# Altium schematic sheet 2-Power.SchDoc(2-Power)
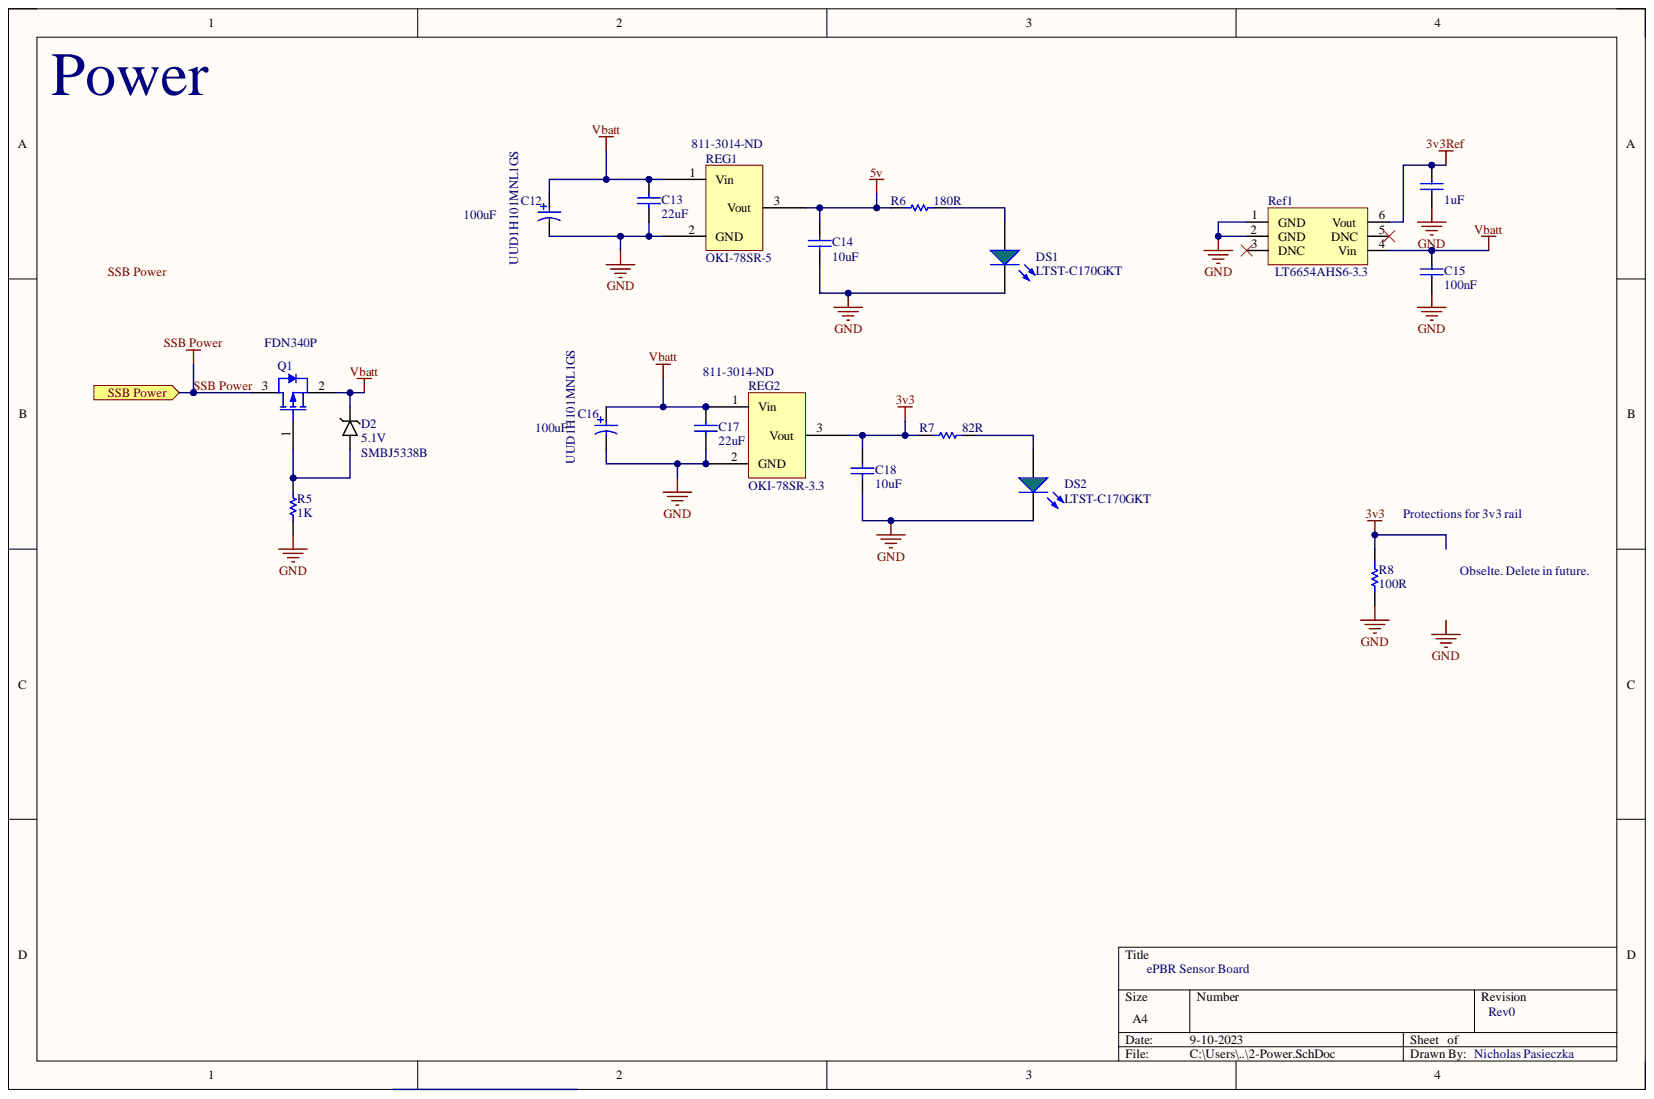
[diagram p0: 2-Power.SchDoc]

## Parts
C12  UUD1H101MNL1GS  [NIC-CAPA2-8X10_V]
C13  value 22uF  [C1210_M]  (hidden comment: Cap)
C14  value 10uF  [C1210_M]  (hidden comment: Cap)
C15  value 100nF  [1608[0603]]  (hidden comment: Cap)
C16  UUD1H101MNL1GS  [NIC-CAPA2-8X10_V]
C17  value 22uF  [C1210_M]  (hidden comment: Cap)
C18  value 10uF  [C1210_M]  (hidden comment: Cap)
D2  Part Number: SMBJ5338B  Reverse Voltage: 5.1V  [DO-214AA (SMB)]  (hidden comment: Zener Diode, 5.1V, 5W SMBJ5338B)
DS1  LTST-C170GKT  [LTST-C170GKT_V]
DS2  LTST-C170GKT  [LTST-C170GKT_V]
Q1  FDN340P  [FAIR-SSOT3L-3_V]
R5  value 1K  [1608[0603]]  (hidden comment: Res1)
R6  value 180R  [1608[0603]]  (hidden comment: Res1)
R7  value 82R  [1608[0603]]  (hidden comment: Res1)
R8  100R  [RESC1206(3216)_M]
Ref1  LT6654AHS6-3.3  [TSOT-23 S6]
REG1  OKI-78SR-5  Supplier Part Number 2: 811-3014-ND  [OKI-78SR-5/1.5-W - Horizontal]
REG2  OKI-78SR-3.3  Supplier Part Number 2: 811-3014-ND  [Reg - TH, 3 pin, 100 mil Pitch, VTL]
  value 1uF  [1608[0603]]  (hidden comment: Cap)

## Ports
SSB Power

## Nets
3v3: C18.2 R7.1 R8.2 REG2.3
3v3Ref: Ref1.6(Vout) .2
5v: C14.2 R6.1 REG1.3
GND: C12.2 C13.1 C14.1 C15.1 C16.2 C17.1 C18.1 DS1.2 DS2.2 R5.1 R8.1 Ref1.1(GND) Ref1.2(GND) REG1.2 REG2.2 .1
Vbatt: C12.1 C13.2 C15.2 C16.1 C17.2 D2.K Q1.2 Ref1.4(Vin) REG1.1 REG2.1
SSB Power: Q1.3 ; port SSB Power
NetD2_A: D2.A Q1.1 R5.2
NetDS1_1: DS1.1 R6.2
NetDS2_1: DS2.1 R7.2

Not connected: Ref1 3(DNC) 5(DNC)

## Library data of the parts
C12 C16 = CMP-0687-00073-1: Aluminum Electrolytic Capacitor, UD Series, Low Impedance, 100 uF, 50 V, + / - 20%, -55 to 105 degC, Chip Type, 8 x 10 mm D x L, Pb-Free, Reel; Manufacturer: Nichicon; Capacitance: 100µF; Endurance Time: 5000 h; Impedance: 0.34 Ohm; Lifetime @ Temp.: 5000 Hrs @ 105°C; Packing: Dia382mm Reel; Polarization: Polar; Rated Capacitance: 100 uF; Rated Ripple Current (at 100kHz): 300 mA; Rated Voltage: 50 V; Ripple Current: 300mA; Surface Mount Land Size: 0.327" L x 0.327" W (8.30mm x 8.30mm); Tolerance Rated Capacitance: -20 to 20%; Voltage Rating: 50V; Applications: General Purpose; Category: Capacitors; Component Kind: Standard; ComponentLink1Description: Manufacturer URL; ComponentLink1URL: http://www.nichicon.co.jp/; ComponentLink2Description: Datasheet; ComponentLink2URL: http://products.nichicon.co.jp/en/pdf/XJA043/e-ud.pdf; ComponentLink3Description: Package Specification; ComponentLink3URL: http://products.nichicon.co.jp/en/pdf/XJA043/e-ud.pdf; ComponentLink4Description: http://nichicon-us.com/english/products/pdfs/e-uud.pdf; ComponentLink4URL: http://nichicon-us.com/english/products/pdfs/e-uud.pdf; DatasheetVersion: 08/2012; Diameter: 8 mm; ESR (Equivalent Series Resistance): -; Height - Seated (Max): 0.394" (10.00mm); Lead Spacing: -; Length: 10 mm; Library Name: Altium Content Vault; Manufacturer Part Number: UUD1H101MNL1GS; Mounting Technology: Surface Mount; Mounting Type: Surface Mount; Operating Temperature: -55°C ~ 105°C; Package / Case: Radial, Can - SMD; PackageDescription: 2-Pin Surface Mount Device, Diameter 8 mm, Height 10 mm, Pitch 6.5 mm; PackageReference: CAPAE-D8H10; Packaging: Cut Tape (CT); Part Status: Active; PartNumber: UUD1H101MNL1GS; Pricing 1: 1=0.92, 10=0.685, 25=0.5868, 50=0.5478, 100=0.4696, 250=0.43044 (CAD); RoHS: TRUE; Size / Dimension: 0.315" Dia (8.00mm); Stock 1: 65948; Supplier 1: Digi-Key; Supplier Part Number 1: 493-2306-1-ND; Tolerance: ±20%
C13 C17 = Cap: Capacitor; Manufacturer: Yageo; Manufacturer Part Number: CC1210MKX5R8BB226; Capacitance: 22µF; Temperature Coefficient: X5R; Tolerance: ±20%; Voltage - Rated: 25V; Applications: General Purpose; Category: Capacitors; Component Kind: Standard; ComponentLink1Description: http://www.yageo.com/documents/recent/UPY-GPHC_X5R_4V-to-50V_23.pdf; ComponentLink1URL: http://www.yageo.com/documents/recent/UPY-GPHC_X5R_4V-to-50V_23.pdf; Failure Rate: -; Features: -; Height - Seated (Max): -; Lead Spacing: -; Lead Style: -; Library Name: Miscellaneous Devices.IntLib; Mounting Type: Surface Mount, MLCC; Operating Temperature: -55°C ~ 85°C; Package / Case: 1210 (3225 Metric); Packaging: Cut Tape (CT); Part Status: Active; Pricing 1: 1=1, 10=0.703, 25=0.5996, 50=0.5314, 100=0.4628, 250=0.39424 (CAD); Ratings: -; RoHS: RoHS Compliant; Simulation: CAP; Size / Dimension: 0.126" L x 0.098" W (3.20mm x 2.50mm); Stock 1: 128; Supplier 1: Digi-Key; Supplier Part Number 1: 311-2056-1-ND; Thickness (Max): 0.110" (2.80mm)
C14 C18 = Cap: Capacitor; Manufacturer: Yageo; Manufacturer Part Number: CC1210ZKY5V8BB106; Capacitance: 10µF; Temperature Coefficient: Y5V (F); Tolerance: -20%, +80%; Voltage - Rated: 25V; Applications: General Purpose; Category: Capacitors; Component Kind: Standard; ComponentLink1Description: http://www.yageo.com/documents/recent/UPY-GPHC_Y5V_6.3V-to-50V_7.pdf; ComponentLink1URL: http://www.yageo.com/documents/recent/UPY-GPHC_Y5V_6.3V-to-50V_7.pdf; Failure Rate: -; Features: -; Height - Seated (Max): -; Lead Spacing: -; Lead Style: -; Library Name: Miscellaneous Devices.IntLib; Mounting Type: Surface Mount, MLCC; Operating Temperature: -30°C ~ 85°C; Package / Case: 1210 (3225 Metric); Packaging: Cut Tape (CT); Part Status: Active; Pricing 1: 1=0.47, 10=0.323, 25=0.258, 50=0.2154, 100=0.1829, 250=0.14528, 500=0.12912, 1000=0.11298 (CAD); Ratings: -; RoHS: RoHS Compliant; Simulation: CAP; Size / Dimension: 0.126" L x 0.098" W (3.20mm x 2.50mm); Stock 1: 1156; Supplier 1: Digi-Key; Supplier Part Number 1: 311-2063-1-ND; Thickness (Max): 0.083" (2.10mm)
C15 = Cap: Capacitor; Manufacturer: Yageo; Manufacturer Part Number: CC0603KRX7R9BB104; Capacitance: 0.10µF; Temperature Coefficient: X7R; Tolerance: ±10%; Voltage - Rated: 50V; Applications: General Purpose; Category: Capacitors; Component Kind: Standard; ComponentLink1Description: http://www.yageo.com/documents/recent/UPY-GPHC_X7R_6.3V-to-50V_16.pdf; ComponentLink1URL: http://www.yageo.com/documents/recent/UPY-GPHC_X7R_6.3V-to-50V_16.pdf; Failure Rate: -; Features: -; Height - Seated (Max): -; Lead Spacing: -; Lead Style: -; Library Name: Miscellaneous Devices.IntLib; Mounting Type: Surface Mount, MLCC; Operating Temperature: -55°C ~ 125°C; Package / Case: 0603 (1608 Metric); Packaging: Cut Tape (CT); Part Status: Active; Pricing 1: 1=0.15, 10=0.043, 25=0.0312, 50=0.0232, 100=0.0189, 250=0.0158, 500=0.01346, 1000=0.01059 (CAD); Ratings: -; RoHS: RoHS Compliant; Simulation: CAP; Size / Dimension: 0.063" L x 0.031" W (1.60mm x 0.80mm); Stock 1: 4016262; Supplier 1: Digi-Key; Supplier Part Number 1: 311-1344-1-ND; Thickness (Max): 0.035" (0.90mm)
DS1 DS2 = CMP-1674-00002-5: LED Uni-Color Green, 100 mW, 120 mA, -55 to 85 degC, 2-Pin SMD, RoHS, Tape and Reel; Manufacturer: Lite-On; Current - Test: 10mA; Lens Color: Colorless; Lens Style/Size: Rectangle with Flat Top, 1.40mm x 1.25mm; Lens Transparency: Clear; Millicandela Rating: 6mcd; Viewing Angle: 130°; Voltage - Forward (Vf) (Typ): 2.1V; Wavelength - Dominant: 569nm; Wavelength - Peak: 565nm; Category: Optoelectronics; Color: Green; Colour: Green; Component Kind: Standard; ComponentLink1Description: Manufacturer URL; ComponentLink1URL: http://www.us.liteon.com/; ComponentLink2Description: Datasheet; ComponentLink2URL: http://media.digikey.com/pdf/Data%20Sheets/Lite-On%20PDFs/LTST-C170GKT.pdf; DatasheetVersion: 03/2003; Features: -; Height: 1.1 mm; Height (Max): 1.10mm; Length: 2 mm; Library Name: Altium Content Vault; Manufacturer Part Number: LTST-C170GKT; Max Operating Temperature: 85 degC; Min Operating Temperature: -55 degC; Mount: Surface Mount; Mounting Technology: Surface Mount; Mounting Type: Surface Mount; Package / Case: 0805 (2012 Metric); Package Quantity: 1; PackageDescription: 2-Pin Surface Mount Device, Body 2 x 1.25 mm; PackageReference: C170GKT; Packaging: Tape and Reel; Part Status: Active; Pins: 2; Pricing 1: 1=0.48, 10=0.351, 25=0.2588, 100=0.1848, 250=0.12564, 500=0.11086, 1000=0.08684 (CAD); RoHS: RoHS Compliant; RoHSCompliant: true; Size / Dimension: 2.00mm L x 1.25mm W; Stock 1: 242288; Supplier 1: Digi-Key; Supplier Device Package: -; Supplier Part Number 1: 160-1179-1-ND
Q1 = CMP-2100-03638-1: Single P-Channel, Logic Level, PowerTrench(R) MOSFET, 2 A, 20 V, -55 to 150 degC, 3-Pin SOT-23, RoHS, Tape and Reel; Manufacturer: Fairchild Semiconductor; Continuous Drain Current (ID): 2A; Current Rating: -2A; Drain to Source Breakdown Voltage: -20V; Drain to Source Resistance: 60mOhm; Drain to Source Voltage (Vdss): 20V; Fall Time: 11ns; Gate to Source Voltage (Vgs): 8V; Input Capacitance: 779pF; Max Power Dissipation: 500mW; Number of Elements: 1; Package Version: Rev. 3, 05/2015; Rds On Max: 70mOhm; Rise Time: 9ns; Threshold Voltage: -800mV; Turn-Off Delay Time: 27ns; Turn-On Delay Time: 10ns; Case/Package: SOT-23; Component Kind: Standard; Contact Plating: Tin; Datasheet URL: https://www.fairchildsemi.com/datasheets/FD/FDN340P.pdf; Datasheet Version: Rev. E1, 02/2007; Element Configuration: Single; Height: 0.94mm; Lead Free: LeadFree; Length: 2.92mm; Library Name: Altium Content Vault; Manufacturer URL: https://www.fairchildsemi.com/; Max Operating Temperature: 150degC; Min Operating Temperature: -55degC; Mount: SurfaceMount; Number of Pins: 3; Package Description: 3-Pin Small Outline Transistor, Body 2.92 x 1.4 mm, Pitch 0.95 mm; Package Quantity: 1; Package Reference: SSOT3L; Package URL: https://www.fairchildsemi.com/package-drawings/MA/MA03B.pdf; Packaging: TapeandReel; Power Dissipation: 500mW; Radiation Hardening: No; REACH SVHC: NoSVHC; RoHSCompliant: true; Termination: SMD/SMT; Voltage Rating (DC): -20V; Width: 3.05mm
R5 = Res1: Resistor; Manufacturer: Panasonic Electronic Components; Manufacturer Part Number: ERJ-PA3F1001V; Power (Watts): 0.25W, 1/4W; Temperature Coefficient: ±100ppm/°C; Tolerance: ±1%; Category: Resistors; Component Kind: Standard; ComponentLink1Description: https://industrial.panasonic.com/cdbs/www-data/pdf/RDA0000/AOA0000C243.pdf; ComponentLink1URL: https://industrial.panasonic.com/cdbs/www-data/pdf/RDA0000/AOA0000C243.pdf; Composition: Thick Film; Failure Rate: -; Features: Automotive AEC-Q200, Pulse Withstanding; Height - Seated (Max): 0.022" (0.55mm); Library Name: Miscellaneous Devices.IntLib; Number of Terminations: 2; Operating Temperature: -55°C ~ 155°C; Package / Case: 0603 (1608 Metric); Packaging: Cut Tape (CT); Part Status: Active; Pricing 1: 1=0.22, 10=0.198, 25=0.138, 50=0.1072, 100=0.0765, 250=0.05972, 500=0.04746, 1000=0.03368 (CAD); Resistance (Ohms): 1k; RoHS: RoHS Compliant; Simulation: RESISTOR; Size / Dimension: 0.063" L x 0.031" W (1.60mm x 0.80mm); Stock 1: 56110; Supplier 1: Digi-Key; Supplier Device Package: 0603; Supplier Part Number 1: P1.0KBYCT-ND
R6 = Res1: Resistor; Manufacturer: Yageo; Manufacturer Part Number: RC0603JR-07180RL; Power (Watts): 0.1W, 1/10W; Resistance (Ohms): 180; Temperature Coefficient: ±100ppm/°C; Tolerance: ±5%; Category: Resistors; Component Kind: Standard; ComponentLink1Description: http://www.yageo.com/NewPortal/yageodocoutput?fileName=/pdf/R-Chip/PYu-RC_Group_51_RoHS_L_4.pdf; ComponentLink1URL: http://www.yageo.com/NewPortal/yageodocoutput?fileName=/pdf/R-Chip/PYu-RC_Group_51_RoHS_L_4.pdf; Composition: Thick Film; Failure Rate: -; Features: Moisture Resistant; Height - Seated (Max): 0.022" (0.55mm); Library Name: Miscellaneous Devices.IntLib; Number of Terminations: 2; Operating Temperature: -55°C ~ 155°C; Package / Case: 0603 (1608 Metric); Packaging: Cut Tape (CT); Part Status: Active; Pricing 1: 1=0.16, 10=0.016, 25=0.0112, 50=0.0084, 100=0.0062, 250=0.0048, 500=0.00382, 1000=0.00281 (CAD); RoHS: RoHS Compliant; Simulation: RESISTOR; Size / Dimension: 0.063" L x 0.031" W (1.60mm x 0.80mm); Stock 1: 201936; Supplier 1: Digi-Key; Supplier Device Package: 0603; Supplier Part Number 1: 311-180GRCT-ND
R7 = Res1: Resistor; Manufacturer: Yageo; Manufacturer Part Number: RC0603JR-0782RL; Power (Watts): 0.1W, 1/10W; Resistance (Ohms): 82; Temperature Coefficient: ±100ppm/°C; Tolerance: ±5%; Category: Resistors; Component Kind: Standard; ComponentLink1Description: http://www.yageo.com/NewPortal/yageodocoutput?fileName=/pdf/R-Chip/PYu-RC_Group_51_RoHS_L_4.pdf; ComponentLink1URL: http://www.yageo.com/NewPortal/yageodocoutput?fileName=/pdf/R-Chip/PYu-RC_Group_51_RoHS_L_4.pdf; Composition: Thick Film; Failure Rate: -; Features: Moisture Resistant; Height - Seated (Max): 0.022" (0.55mm); Library Name: Miscellaneous Devices.IntLib; Number of Terminations: 2; Operating Temperature: -55°C ~ 155°C; Package / Case: 0603 (1608 Metric); Packaging: Cut Tape (CT); Part Status: Active; Pricing 1: 1=0.16, 10=0.016, 25=0.0112, 50=0.0084, 100=0.0062, 250=0.0048, 500=0.00382, 1000=0.00281 (CAD); RoHS: RoHS Compliant; Simulation: RESISTOR; Size / Dimension: 0.063" L x 0.031" W (1.60mm x 0.80mm); Stock 1: 72049; Supplier 1: Digi-Key; Supplier Device Package: 0603; Supplier Part Number 1: 311-82GRCT-ND
R8 = CMP-1014-00050-1: 100R 0.25W 5% 1206 (3216 Metric)  SMD; Case-EIA: 1206; Case-Metric: 3216; Value: 100.00 Ohm; Component Kind: Standard; Library Name: Altium Content Vault; Power: 0.25 W; Technology: SMT; Tolerance: 5 %
Ref1 = LT6654AHS6-3.3: Manufacturer: Linear Technology; Current - Output: 10mA; Current - Supply: 600µA; Noise - 0.1Hz to 10Hz: 1.7ppmp-p; Noise - 10Hz to 10kHz: 2ppmrms; Output Type: Fixed; Reference Type: Series; Temperature Coefficient: 10ppm/°C; Tolerance: ±0.05%; Voltage - Input: 3.8 V ~ 36 V; Voltage - Output (Min/Fixed): 3.3V; Category: Integrated Circuits (ICs); Component Kind: Standard; ComponentLink1Description: http://www.linear.com/docs/29677; ComponentLink1URL: http://www.linear.com/docs/29677; Current - Cathode: -; Digikey Link: http://www.digikey.com/product-detail/en/linear-technology/LT6654AHS6-3.3-TRMPBF/LT6654AHS6-3.3-TRMPBFCT-ND/2485839; Digikey Part Number: LT6654AHS6-3.3#TRMPBFCT-ND; Manufacturer Part Number: LT6654AHS6-3.3; Mounting Type: Surface Mount; Operating Temperature: -40°C ~ 125°C (TA); Package / Case: SOT-23-6 Thin, TSOT-23-6; Packaging: Cut Tape (CT); Part Status: Active; Pricing 1: 1=9.53, 25=8.508, 100=5.5596, 250=5.1048 (CAD); RoHS: RoHS Compliant; Stock 1: 1315; Supplier 1: Digi-Key; Supplier Device Package: TSOT-23-6; Supplier Part Number 1: LT6654AHS6-3.3#TRMPBFCT-ND; Voltage - Output (Max): -; Library Name: VCU Library.SchLib
REG1 = OKI-78SR-5: 5V DCDC; Manufacturer: Murata Power Solutions Inc.; Manufacturer Part Number: OKI-78SR-3.3/1.5-W36H-C; Power (Watts) - Manufacture Series: 5W; Current - Output (Max): 1.5A; Efficiency: 85.5%; Number of Outputs: 1; Voltage - Input (Max): 36V; Voltage - Input (Min): 7V; Applications: ITE (Commercial); Category: Power Supplies - Board Mount; Component Kind: Standard; ComponentLink1Description: http://power.murata.com/data/power/oki-78sr.pdf; ComponentLink1URL: http://power.murata.com/data/power/oki-78sr.pdf; Features: SCP; Library Name: FE Schem Lib.SchLib; Mounting Type: Through Hole; Operating Temperature: -40°C ~ 85°C; Package / Case: 3-SIP Module; Packaging: Tray; Part Status: Active; Pricing 2: 1=6.31, 5=6.248, 10=6.205, 25=6.1168, 50=5.9262, 100=5.5449, 250=5.28716 (CAD); RoHS: RoHS Compliant; Size / Dimension: 0.65" L x 0.41" W x 0.30" H (16.5mm x 10.4mm x 7.6mm); Stock 2: 4108; Supplier 1: Digi-Key; Supplier 2: Digi-Key; Supplier Part Number 1: 811-2196-5-ND; Type: Linear Regulator Replacement; Voltage - Isolation: -; Voltage - Output 1: 5V; Voltage - Output 2: -; Voltage - Output 3: -
REG2 = OKI-78SR-5: 3.3V DCDC; Manufacturer: Murata Power Solutions Inc.; Manufacturer Part Number: OKI-78SR-3.3/1.5-W36H-C; Power (Watts) - Manufacture Series: 5W; Current - Output (Max): 1.5A; Efficiency: 85.5%; Number of Outputs: 1; Voltage - Input (Max): 36V; Voltage - Input (Min): 7V; Applications: ITE (Commercial); Category: Power Supplies - Board Mount; Component Kind: Standard; ComponentLink1Description: http://power.murata.com/data/power/oki-78sr.pdf; ComponentLink1URL: http://power.murata.com/data/power/oki-78sr.pdf; Features: SCP; Library Name: FE Schem Lib.SchLib; Mounting Type: Through Hole; Operating Temperature: -40°C ~ 85°C; Package / Case: 3-SIP Module; Packaging: Tray; Part Status: Active; Pricing 2: 1=6.31, 5=6.248, 10=6.205, 25=6.1168, 50=5.9262, 100=5.5449, 250=5.28716 (CAD); RoHS: RoHS Compliant; Size / Dimension: 0.65" L x 0.41" W x 0.30" H (16.5mm x 10.4mm x 7.6mm); Stock 2: 4108; Supplier 1: Digi-Key; Supplier 2: Digi-Key; Supplier Part Number 1: 811-2196-5-ND; Type: Linear Regulator Replacement; Voltage - Isolation: -; Voltage - Output 1: 3.3V; Voltage - Output 2: -; Voltage - Output 3: -
 = Cap: Capacitor; Manufacturer: Murata Electronics North America; Manufacturer Part Number: GRM188R61E105KA12D; Capacitance: 1µF; Temperature Coefficient: X5R; Tolerance: ±10%; Voltage - Rated: 25V; Applications: General Purpose; Category: Capacitors; Component Kind: Standard; ComponentLink1Description: http://www.murata.com/~/media/webrenewal/support/library/catalog/products/capacitor/mlcc/c02e.pdf; ComponentLink1URL: http://www.murata.com/~/media/webrenewal/support/library/catalog/products/capacitor/mlcc/c02e.pdf; Features: -; Height - Seated (Max): -; Lead Spacing: -; Lead Style: -; Library Name: Miscellaneous Devices.IntLib; Mounting Type: Surface Mount, MLCC; Operating Temperature: -55°C ~ 85°C; Package / Case: 0603 (1608 Metric); Packaging: Cut Tape (CT); Part Status: Active; Pricing 1: 1=0.15, 10=0.053, 50=0.0288, 100=0.0242, 250=0.01984, 500=0.01696, 1000=0.0132 (CAD); Ratings: -; RoHS: RoHS Compliant; Simulation: CAP; Size / Dimension: 0.063" L x 0.031" W (1.60mm x 0.80mm); Stock 1: 4259407; Supplier 1: Digi-Key; Supplier Part Number 1: 490-3897-1-ND; Thickness (Max): 0.035" (0.90mm)
D2 = Zener Diode, 5.1V, 5W SMBJ5338B: Component Kind: Standard; Power: 5W; Library Name: VCU Library.SchLib
